# Altium schematic sheet Power.SchDoc
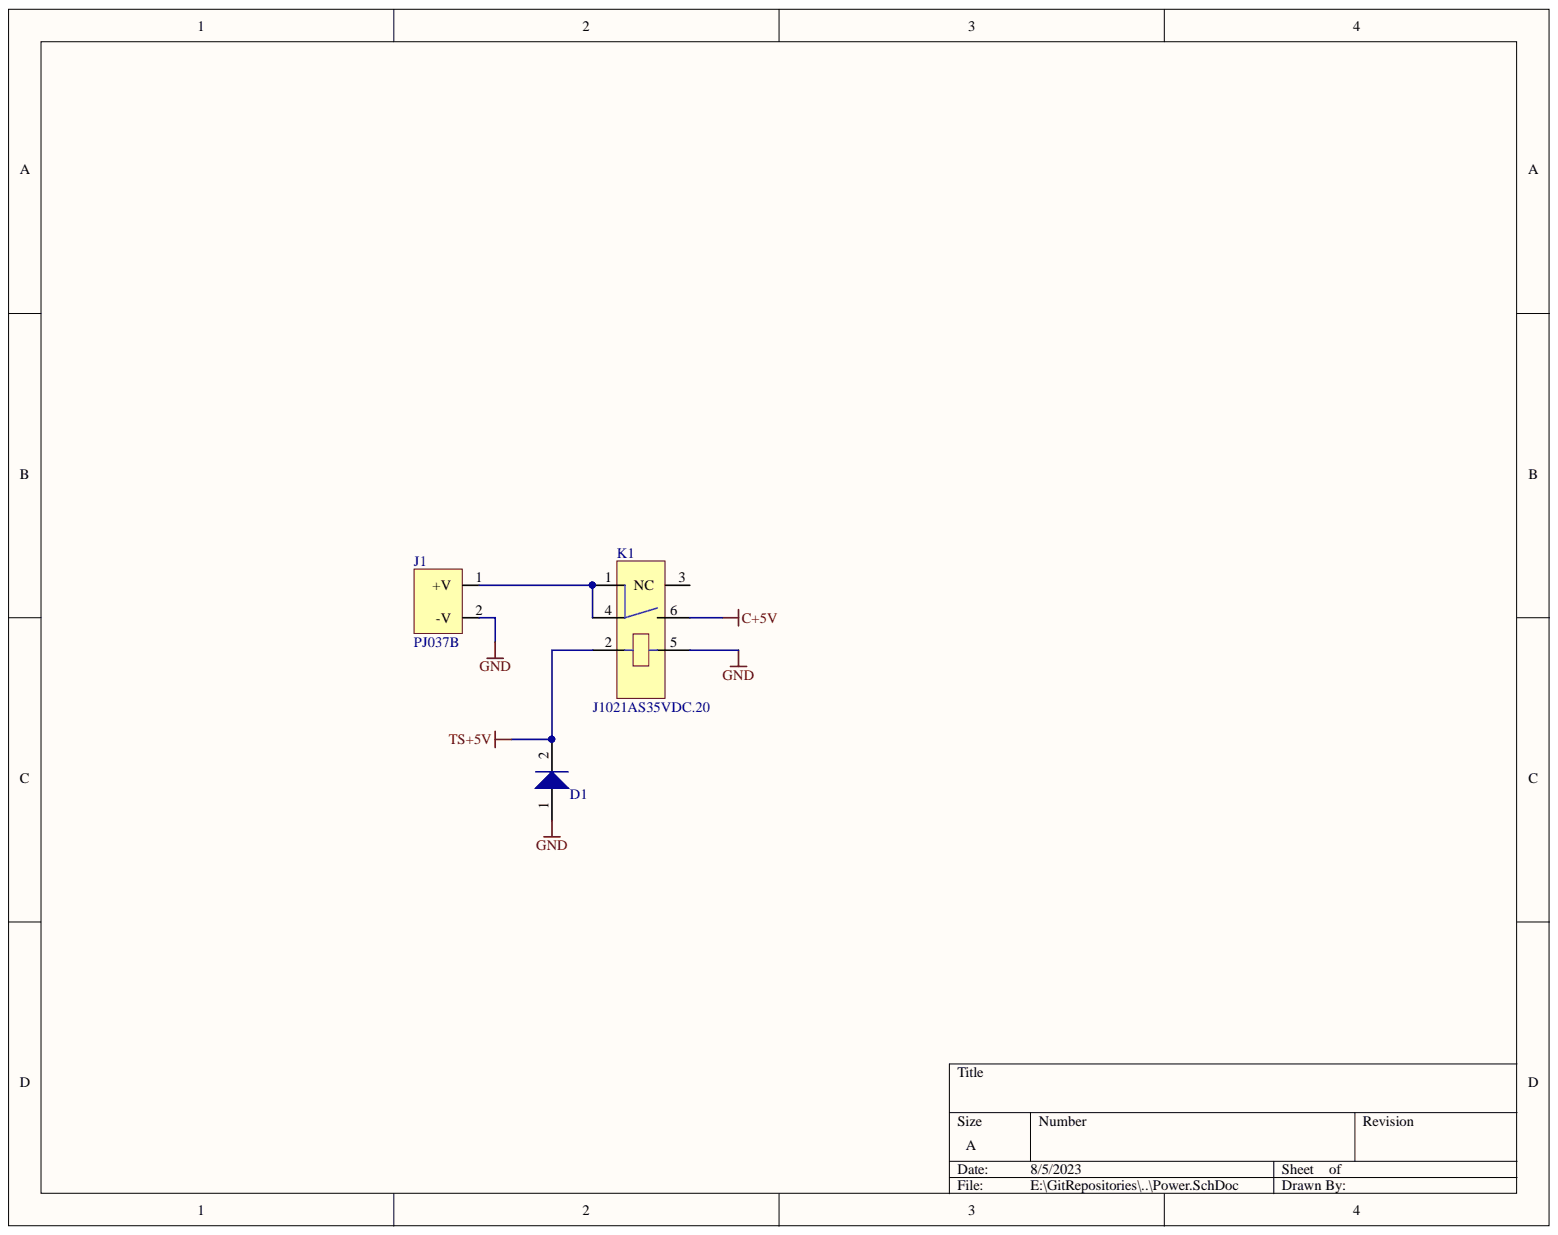
[diagram p0: Power.SchDoc]

## Parts
D1  1N4004  [DO-41]  (value not drawn)
J1  PJ037B  [PJ037B]
K1  J1021AS35VDC.20  [HE7X]

## Nets
C+5V: K1.6
GND: D1.1 J1.2 K1.5
TS+5V: D1.2 K1.2
NetJ1_1: J1.1 K1.1(NC) K1.4

Not connected: K1 3

## Library data of the parts
D1 = 1N4004: Diode 400 V 1A Through Hole DO-41; DK PN: N4004DICT-ND; MFG: Diodes Inc; MFG PN: 1N4004-T; Component Kind: Standard; Library Name: MiscSemi.SchLib
J1 = PJ037B: Power Barrel Connector Jack 2.50mm ID (0.098"), 5.50mm OD (0.217") Through Hole, Right Angle; DK PN: CP-037B-ND; MFG: CUI; MFG PN: PJ-037B; Component Kind: Standard; Library Name: APN.SchLib
K1 = J1021AS35VDC.20: RELAY GEN PURPOSE SPST 3A 5V; DK PN: 2449-J1021AS35VDC.20-ND; MFG: CIT; Component Kind: Standard; Library Name: Power.SchLib; MFG PN: J1021AS35VDC.20
